# Altium schematic sheet Wireless.Sch
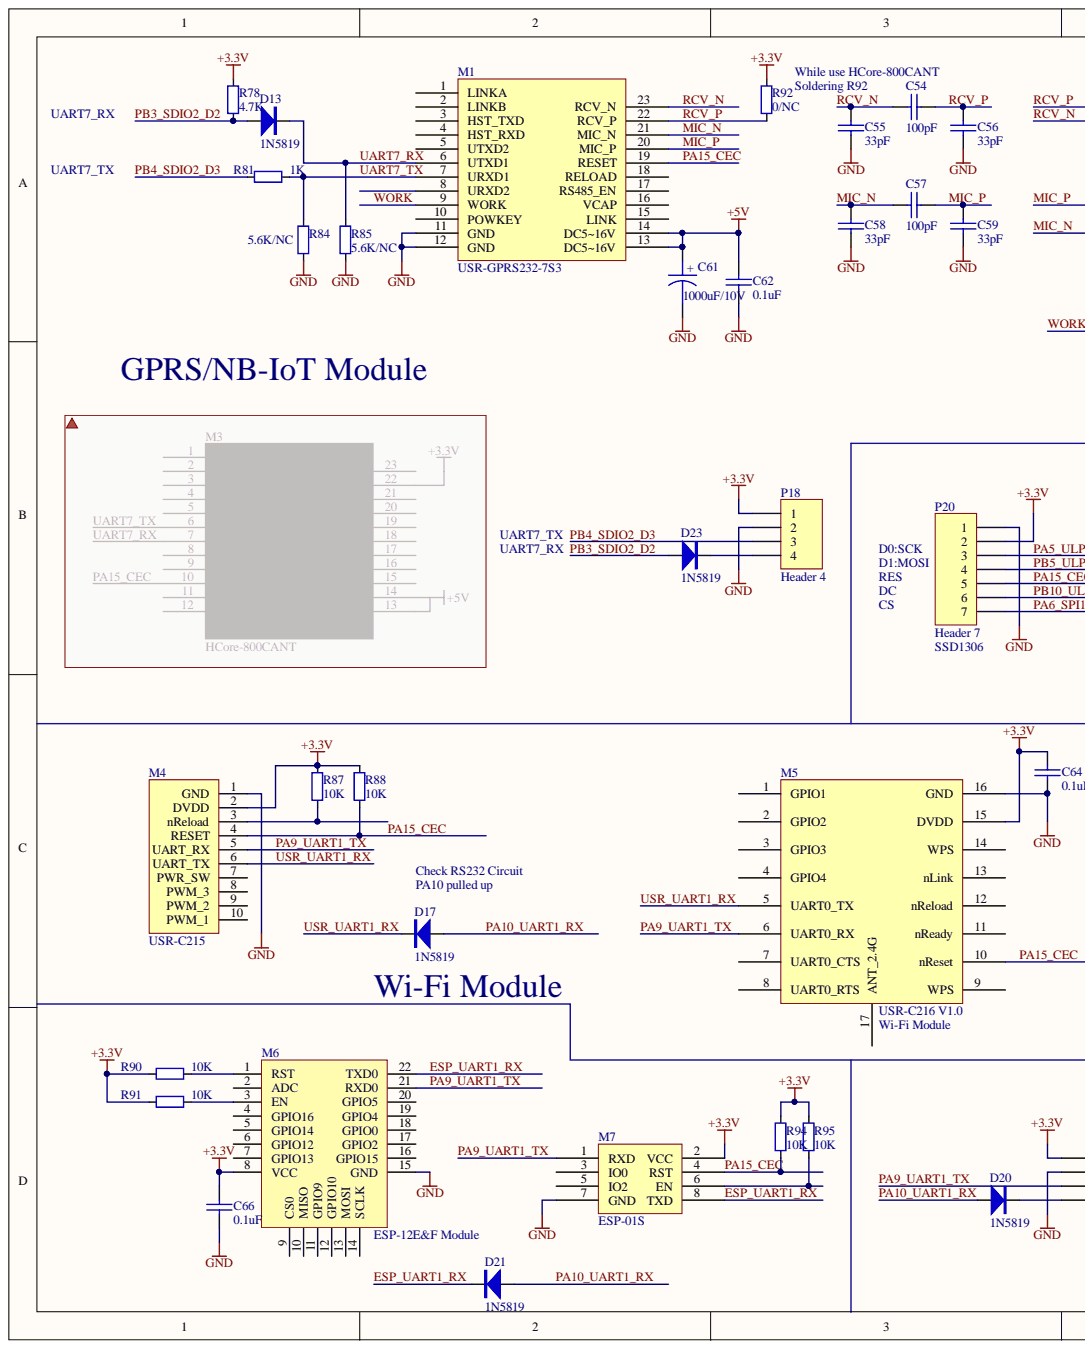
[diagram p0: Wireless.Sch, left]
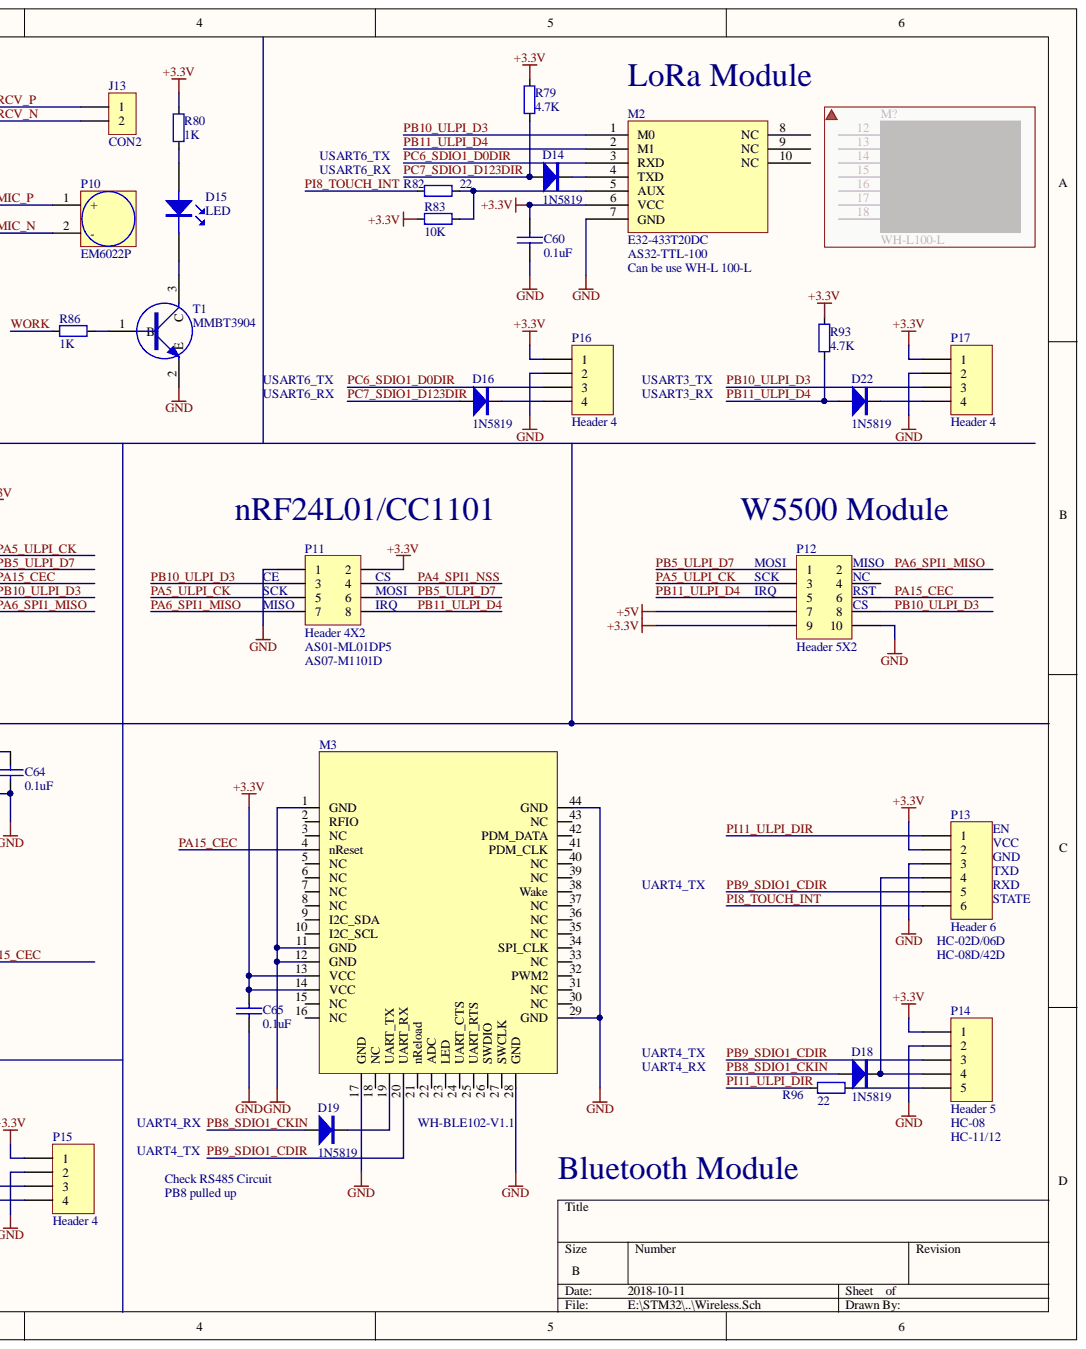
[diagram p1: Wireless.Sch, right]

## Parts
C54  100pF  [0402_C]
C55  33pF  [0402_C]
C56  33pF  [0402_C]
C57  100pF  [0402_C]
C58  33pF  [0402_C]
C59  33pF  [0402_C]
C60  0.1uF  [0402_C]
C61  1000uF/10V  [1000uF/10V]
C62  0.1uF  [0402_C]
C64  0.1uF  [0402_C]
C65  0.1uF  [0402_C]
C66  0.1uF  [0402_C]
D13  1N5819  [SOD-123A]
D14  1N5819  [SOD-123A]
D15  LED  [0603_LED]
D16  1N5819  [SOD-123A]
D17  1N5819  [SOD-123A]
D18  1N5819  [SOD-123A]
D19  1N5819  [SOD-123A]
D20  1N5819  [SOD-123A]
D21  1N5819  [SOD-123A]
D22  1N5819  [SOD-123A]
D23  1N5819  [SOD-123A]
J13  CON2  [1x02]
M1  USR-GPRS232-7S3  [USR-GPRS232-7S3]
M2  E32-433T20DC  [PE32(433T20DC)]
M3  WH-BLE102-V1.1  [WH-BLE102-V1.1]
M4  USR-C215  [USR-WIFI232-T]
M5  USR-C216 V1.0  [USR-C216 V1.0]
M6  ESP-12E&F Module  [ESP-12E&F Module]
M7  ESP-01S  [2X4]
P10  EM6022P  [MIC_WM-63PR]
P11  Header 4X2  [AS01-ML01DP5]
P12  Header 5X2  [W5500]
P13  Header 6  [1X06]
P14  Header 5  [1X05]
P15  Header 4  [1X04]
P16  Header 4  [1X04]
P17  Header 4  [1X04]
P18  Header 4  [1X04]
P20  Header 7  [1X07]
R78  4.7K  [0402_R]
R79  4.7K  [0402_R]
R80  1K  [0402_R]
R81  1K  [0402_R]
R82  22  [0402_R]
R83  10K  [0402_R]
R84  5.6K/NC  [0402_R]
R85  5.6K/NC  [0402_R]
R86  1K  [0402_R]
R87  10K  [0402_R]
R88  10K  [0402_R]
R90  10K  [0402_R]
R91  10K  [0402_R]
R92  0/NC  [0402_R]
R93  4.7K  [0402_R]
R94  10K  [0402_R]
R95  10K  [0402_R]
R96  22  [0402_R]
T1  MMBT3904  [SOT_23]

## Nets
+3.3V: C60.2 C64.2 C65.2 C66.2 M2.6(VCC) M3.13(VCC) M3.14(VCC) M4.2(DVDD) M5.15(DVDD) M6.8(VCC) M7.2(VCC) P11.2 P12.9 P13.2 P14.1 P15.1 P16.1 P17.1 P18.1 P20.2 R78.1 R79.1 R80.1 R83.1 R87.1 R88.1 R90.1 R91.1 R92.1 R93.1 R94.1 R95.1
+5V: C61.1 C62.1 M1.13(DC5~16V) M1.14(DC5~16V) P12.7
GND: C55.2 C56.2 C58.2 C59.2 C60.1 C61.2 C62.2 C64.1 C65.1 C66.1 M1.11(GND) M1.12(GND) M2.7(GND) M3.1(GND) M3.11(GND) M3.12(GND) M3.17(GND) M3.28(GND) M3.29(GND) M3.44(GND) M4.1(GND) M5.16(GND) M6.15(GND) M7.7(GND) P11.1 P12.10 P13.3 P14.2 P15.2 P16.2 P17.2 P18.2 P20.1 R84.2 R85.2 T1.2
ESP_UART1_RX: D21.2 M6.22(TXD0) M7.8(TXD)
MIC_N: C57.2 C58.1 M1.21(MIC_N) P10.2
MIC_P: C57.1 C59.1 M1.20(MIC_P) P10.1
PA4_SPI1_NSS: P11.4
PA5_ULPI_CK: P11.5 P12.3 P20.3
PA6_SPI1_MISO: P11.7 P12.2 P20.7
PA9_UART1_TX: M4.5(UART_RX) M5.6(UART0_RX) M6.21(RXD0) M7.1(RXD) P15.3
PA10_UART1_RX: D17.1 D20.1 D21.1
PA15_CEC: M1.19(RESET) M3.4(nReset) M4.4(RESET) M5.10(nReset) M7.4(RST) P12.6 P20.5 R88.2 R94.2
PB3_SDIO2_D2: D13.1 D23.1 R78.2
PB4_SDIO2_D3: P18.3 R81.2
PB5_ULPI_D7: P11.6 P12.1 P20.4
PB8_SDIO1_CKIN: D18.1 D19.1
PB9_SDIO1_CDIR: M3.20(UART_RX) P13.5 P14.3
PB10_ULPI_D3: M2.1(M0) P11.3 P12.8 P17.3 P20.6
PB11_ULPI_D4: D22.1 M2.2(M1) P11.8 P12.5 R93.2
PC6_SDIO1_D0DIR: M2.3(RXD) P16.3
PC7_SDIO1_D123DIR: D14.1 D16.1 R79.2
PI8_TOUCH_INT: P13.6 R82.2
PI11_ULPI_DIR: P13.1 R96.1
RCV_N: C54.2 C55.1 J13.2 M1.23(RCV_N)
RCV_P: C54.1 C56.1 J13.1 M1.22(RCV_P) R92.2
UART7_RX: D13.2 M1.6(UTXD1) R85.1
UART7_TX: M1.7(URXD1) R81.1 R84.1
USR_UART1_RX: D17.2 M4.6(UART_TX) M5.5(UART0_TX)
WORK: M1.9(WORK) R86.2
NetD14_2: D14.2 M2.4(TXD)
NetD15_1: D15.1 R80.2
NetD15_2: D15.2 T1.3
NetD16_2: D16.2 P16.4
NetD18_2: D18.2 P13.4 P14.4
NetD19_2: D19.2 M3.19(UART_TX)
NetD20_2: D20.2 P15.4
NetD22_2: D22.2 P17.4
NetD23_2: D23.2 P18.4
NetM2_5: M2.5(AUX) R82.1 R83.2
NetM4_3: M4.3(nReload) R87.2
NetM6_1: M6.1(RST) R90.2
NetM6_3: M6.3(EN) R91.2
NetM7_6: M7.6(EN) R95.2
NetP14_5: P14.5 R96.2
NetR86_1: R86.1 T1.1
N00420:

Not connected: M1 1(LINKA) 2(LINKB) 3(HST_TXD) 4(HST_RXD) 5(UTXD2) 8(URXD2) 10(POWKEY) 15(LINK) 16(VCAP) 17(RS485_EN) 18(RELOAD); M2 8(NC) 9(NC) 10(NC); M3 2(RFIO) 3(NC) 5(NC) 6(NC) 7(NC) 8(NC) 9(I2C_SDA) 10(I2C_SCL) 15(NC) 16(NC) 18(NC) 21(nReload) 22(ADC) 23(LED) 24(UART_CTS) 25(UART_RTS) 26(SWDIO) 27(SWCLK) 30(NC) 31(NC) 32(PWM2) 33(NC) 34(SPI_CLK) 35(NC) 36(NC) 37(NC) 38(Wake) 39(NC) 40(NC) 41(PDM_CLK) 42(PDM_DATA) 43(NC); M4 7(PWR_SW) 8(PWM_3) 9(PWM_2) 10(PWM_1); M5 1(GPIO1) 2(GPIO2) 3(GPIO3) 4(GPIO4) 7(UART0_CTS) 8(UART0_RTS) 9(WPS) 11(nReady) 12(nReload) 13(nLink) 14(WPS) 17(ANT_2.4G); M6 2(ADC) 4(GPIO16) 5(GPIO14) 6(GPIO12) 7(GPIO13) 9(CS0) 10(MISO) 11(GPIO9) 12(GPIO10) 13(MOSI) 14(SCLK) 16(GPIO15) 17(GPIO2) 18(GPIO0) 19(GPIO4) 20(GPIO5); M7 3(IO0) 5(IO2); P12 4

## Library data of the parts
C54-C59 C62 = CAP: Capacitor; Component Kind: Standard
C60 C64-C66 = CAP: Component Kind: Standard
C61 = CAPACITOR POL: Capacitor; Component Kind: Standard
D13 D14 D16-D23 = 1N5819: Diode; Component Kind: Standard; Simulation: 1N5819
J13 = CON2: Connector; Component Kind: Standard
M4 = USR-WIF232-T: Component Kind: Standard
P11 = Header 4X2: Header, 4-Pin, Dual row; Component Kind: Standard; LatestRevisionDate: 17-Jul-2002; LatestRevisionNote: Re-released for DXP Platform.; Library Name: Miscellaneous Connectors.IntLib; Signal Integrity: Connector; Publisher: Altium Limited
P12 = Header 5X2: Header, 5-Pin, Dual row; Component Kind: Standard; LatestRevisionDate: 17-Jul-2002; LatestRevisionNote: Re-released for DXP Platform.; Library Name: Miscellaneous Connectors.IntLib; Signal Integrity: Connector; Publisher: Altium Limited
P13 = Header 6: Header, 6-Pin; Component Kind: Standard; LatestRevisionDate: 17-Jul-2002; LatestRevisionNote: Re-released for DXP Platform.; Library Name: Miscellaneous Connectors.IntLib; Signal Integrity: Connector; Publisher: Altium Limited
P14 = Header 5: Header, 5-Pin; Component Kind: Standard; LatestRevisionDate: 17-Jul-2002; LatestRevisionNote: Re-released for DXP Platform.; Library Name: Miscellaneous Connectors.IntLib; Signal Integrity: Connector; Publisher: Altium Limited
P15-P18 = Header 4: Header, 4-Pin; Component Kind: Standard; LatestRevisionDate: 17-Jul-2002; LatestRevisionNote: Re-released for DXP Platform.; Library Name: Miscellaneous Connectors.IntLib; Signal Integrity: Connector; Publisher: Altium Limited
P20 = Header 7: Header, 7-Pin; Component Kind: Standard; LatestRevisionDate: 17-Jul-2002; LatestRevisionNote: Re-released for DXP Platform.; Library Name: Miscellaneous Connectors.IntLib; Signal Integrity: Connector; Publisher: Altium Limited
R78-R88 R90-R96 = R0805: Resistor 0805; Component Kind: Standard
T1 = NPN-MMSS8050: Component Kind: Standard
D15 = LED: Component Kind: Standard
M1 = USR-GPRS232-7S3: Component Kind: Standard; Library Name: MY-SCHLIB.SchLib
M2 = E32-433T20DC: Component Kind: Standard; Library Name: MY-SCHLIB.SchLib
M3 = WH-BLE102-V1.1: Component Kind: Standard; Library Name: MY-SCHLIB.SchLib
M5 = USR-C216 V1.0: Component Kind: Standard; Library Name: USR-C216 v1.0.SCHLIB
M6 = ESP-12E&F Module: Component Kind: Standard; Description: ESP-12E&F Module; Library Name: MY-SCHLIB.SchLib
M7 = ESP-01S: Component Kind: Standard; Library Name: MY-SCHLIB.SchLib
P10 = EM6022P: Component Kind: Standard; Library Name: MY-SCHLIB.SchLib
